# Altium schematic sheet DoorControllerv2.SchDoc
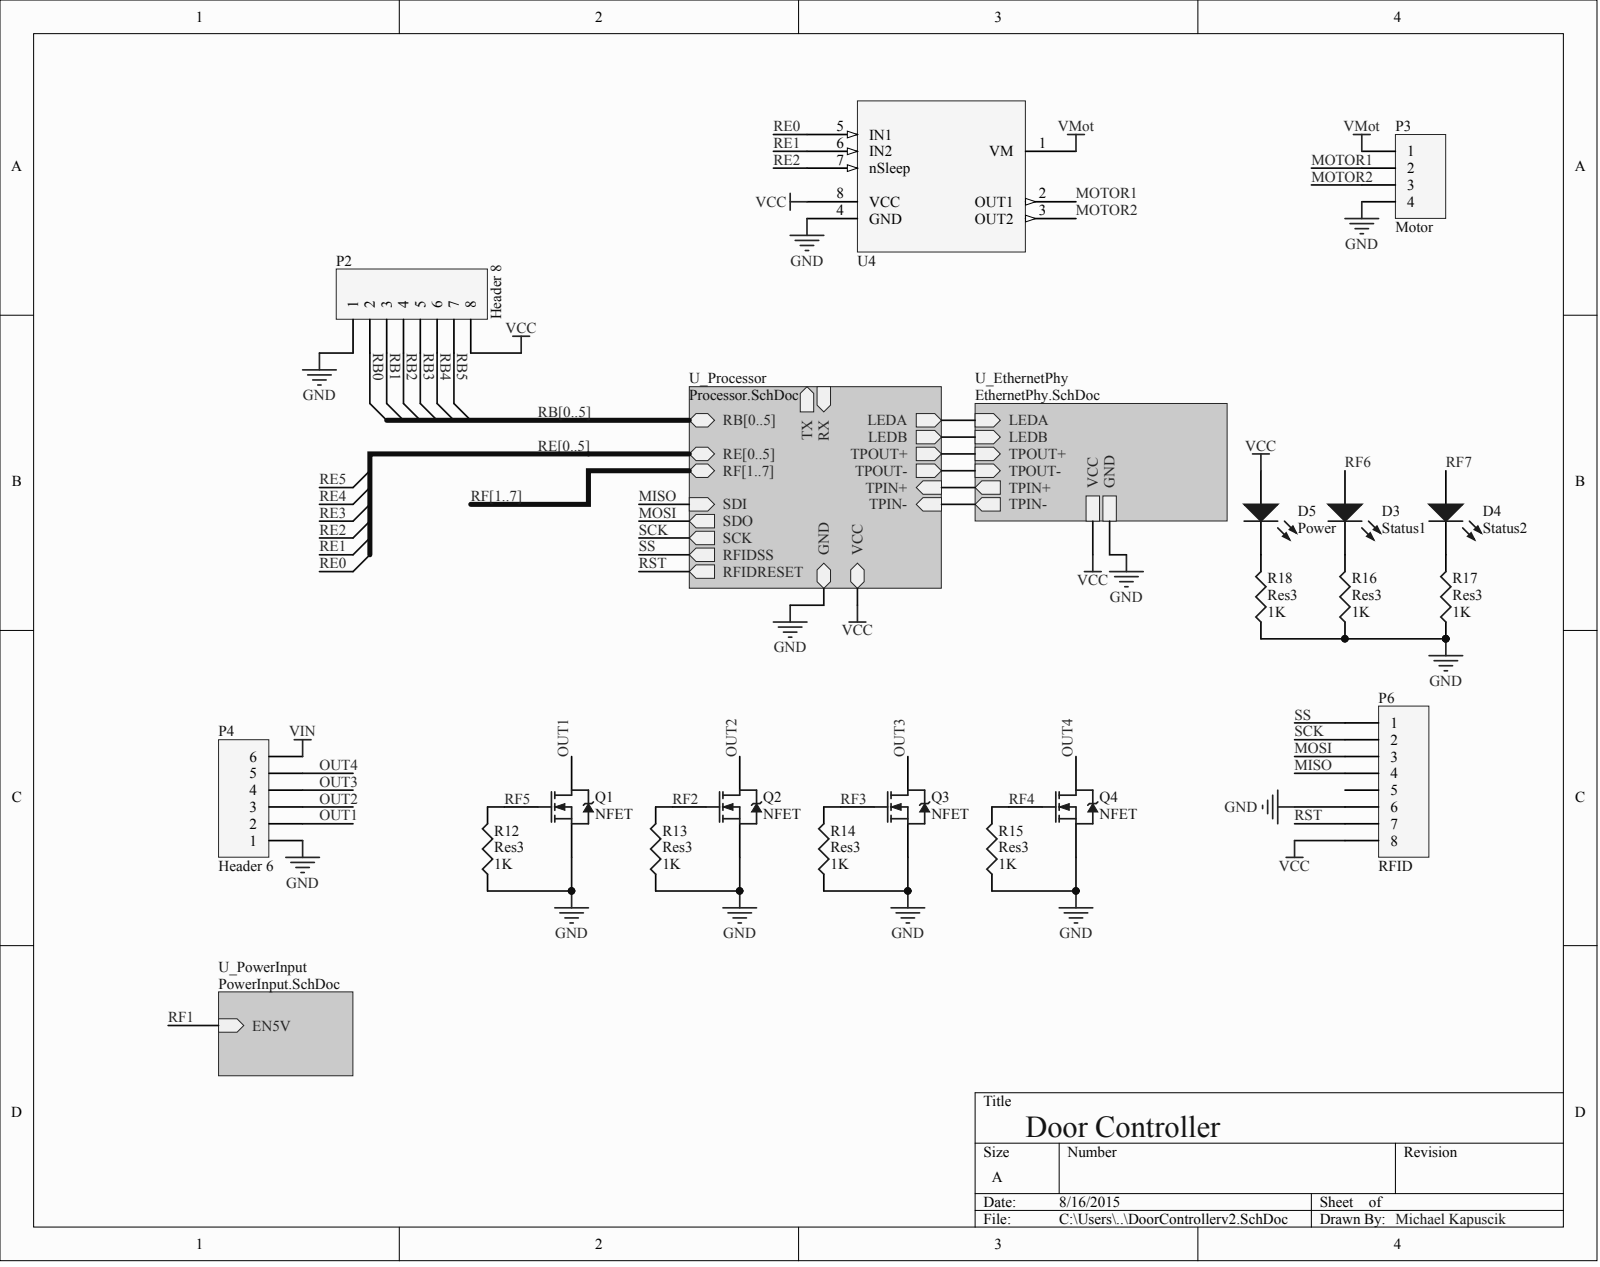
[diagram p0: DoorControllerv2.SchDoc]

## Parts
D3  Status1
D4  Status2
D5  Power
P2  Header 8
P3  Motor
P4  Header 6
P6  RFID
Q1  NFET
Q2  NFET
Q3  NFET
Q4  NFET
R12  Res3  value 1K
R13  Res3  value 1K
R14  Res3  value 1K
R15  Res3  value 1K
R16  Res3  value 1K
R17  Res3  value 1K
R18  Res3  value 1K
U4  1.8A H-Bridge  (value not drawn)

## Nets
GND: P2.1 P3.4 P4.1 P6.6 Q1.2 Q2.2 Q3.2 Q4.2 R12.1 R13.1 R14.1 R15.1 R16.1 R17.1 R18.1 U4.4(GND)
VCC: D5.1 P2.8 P6.8 U4.8(VCC)
VIN: P4.6
VMOT: P3.1 U4.1(VM)
MISO: P6.4
MOSI: P6.3
MOTOR1: P3.2 U4.2(OUT1)
MOTOR2: P3.3 U4.3(OUT2)
OUT1: P4.2 Q1.3
OUT2: P4.3 Q2.3
OUT3: P4.4 Q3.3
OUT4: P4.5 Q4.3
RB0: P2.2  [also labelled RB]
RB1: P2.3  [also labelled RB]
RB2: P2.4  [also labelled RB]
RB3: P2.5  [also labelled RB]
RB4: P2.6  [also labelled RB]
RB5: P2.7  [also labelled RB]
RE0: U4.5(IN1)  [also labelled RE]
RE1: U4.6(IN2)  [also labelled RE]
RE2: U4.7(nSleep)  [also labelled RE]
RF2: Q2.1 R13.2  [also labelled RF]
RF3: Q3.1 R14.2  [also labelled RF]
RF4: Q4.1 R15.2  [also labelled RF]
RF5: Q1.1 R12.2  [also labelled RF]
RF6: D3.1  [also labelled RF]
RF7: D4.1  [also labelled RF]
RST: P6.7
SCK: P6.2
SS: P6.1
NetD3_2: D3.2 R16.2
NetD4_2: D4.2 R17.2
NetD5_2: D5.2 R18.2
NetP6_5: P6.5
LEDA:
LEDB:
RE3:  [also labelled RE]
RE4:  [also labelled RE]
RE5:  [also labelled RE]
RF1:  [also labelled RF]
RX:
TPIN+:
TPIN-:
TPOUT+:
TPOUT-:
TX:

## Library data of the parts
D3-D5 P2-P4 P6 Q1-Q4 R12-R18 U4 = (no symbol name)
  D3-D5: Published: 8-Jun-2000; LatestRevisionDate: 08-Jul-2005; LatestRevisionNote: Mech Layer 1 removed.; PackageReference: LED-0; PackageDescription: LED; 2 Leads
  P2-P4 P6: LatestRevisionDate: 17-Jul-2002; LatestRevisionNote: Re-released for DXP Platform.
  R12-R18: Published: 8-Jun-2000; LatestRevisionDate: 29-May-2009; LatestRevisionNote: IPC-7351 Footprint Added.; PackageReference: J1-0603; PackageDescription: Chip Resistor
  U4: Supplier 1: Digi-Key; Supplier Part Number 1: 296-34806-1-ND
  D3-D5 P2-P4 P6 R12-R18: Publisher: Altium Limited
